# Altium schematic sheet nrf51822.SchDoc
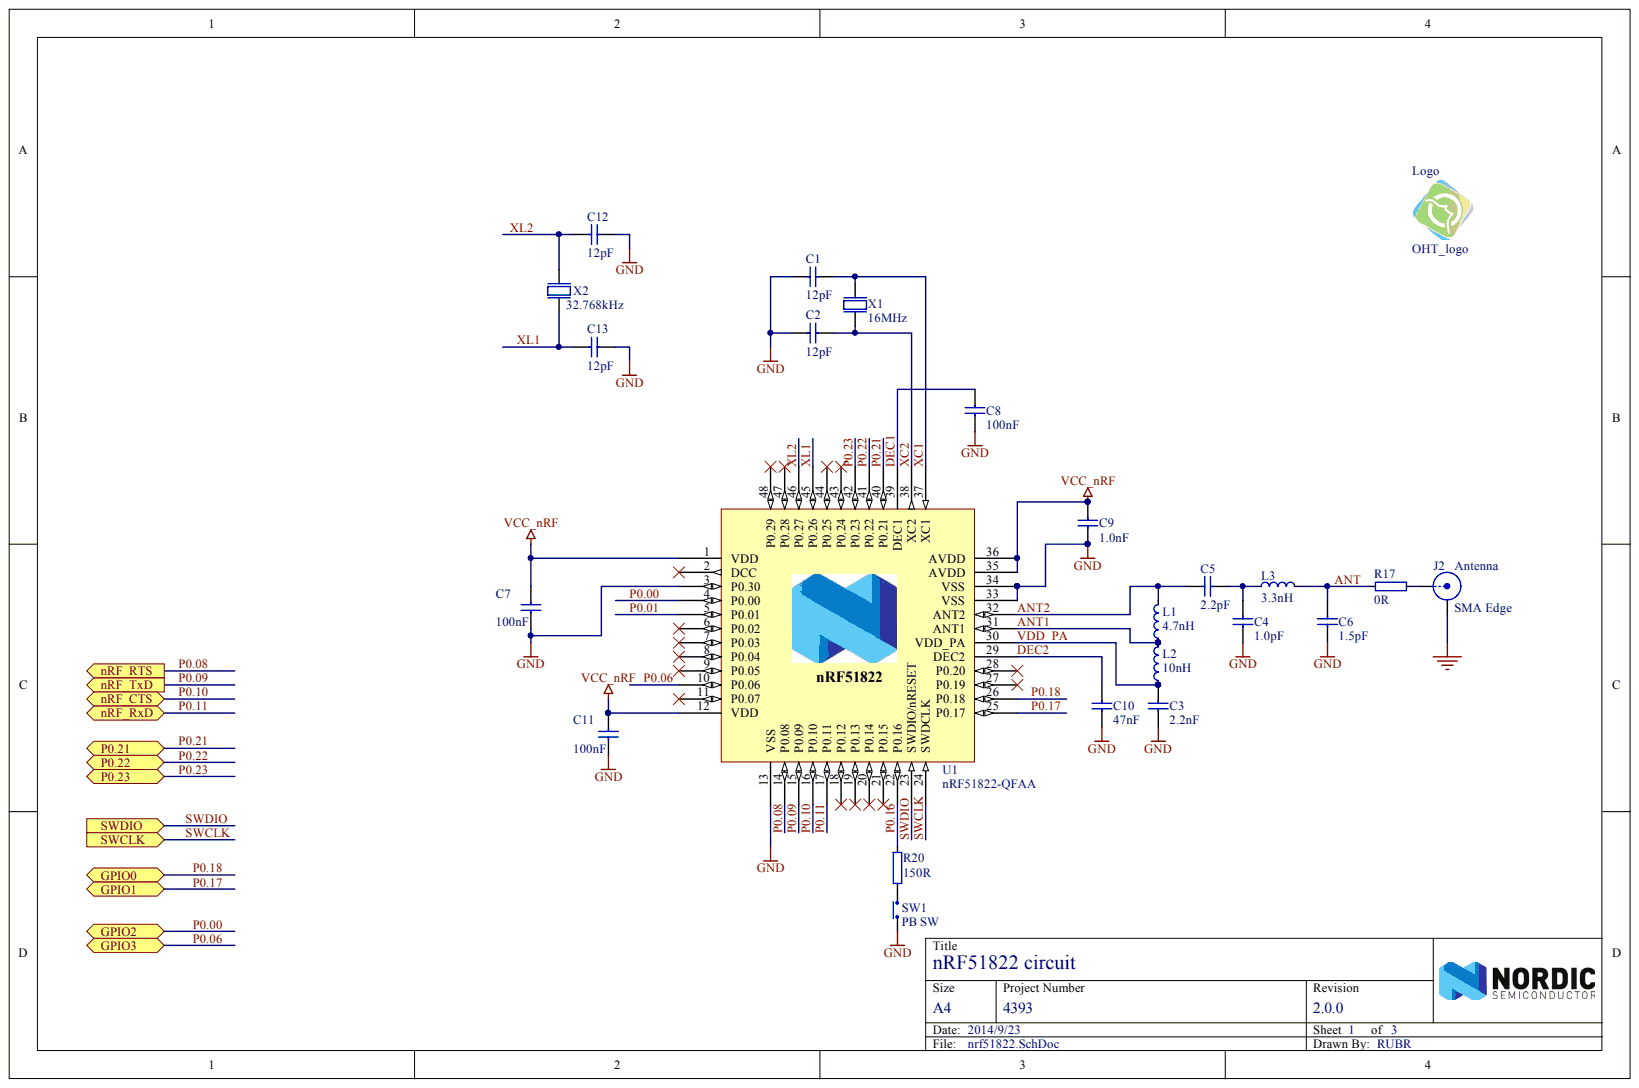
[diagram p0: nrf51822.SchDoc]

## Parts
C1  12pF  [0402]
C2  12pF  [0402]
C3  2.2nF  [0402]
C4  1.0pF  [0402]
C5  2.2pF  [0402]
C6  1.5pF  [0402]
C7  100nF  [0402]
C8  100nF  [0402]
C9  1.0nF  [0402]
C10  47nF  [0402]
C11  100nF  [0402]
C12  12pF  [0402]
C13  12pF  [0402]
J2  SMA Edge  [SMA-EDGE]
L1  4.7nH  [0402]
L2  10nH  [0402]
L3  3.3nH  [0402]
Logo  OHT_logo  [OHTCOM_logo]
R17  0R  [0402]
R20  150R  [0402]
SW1  PB SW  [ALPS_SKHUxxx010_WO_GND]
U1  nRF51822-QFAA  [QFN-48]
X1  16MHz  [BT-XTAL_2520]
X2  32.768kHz  [FC-135]

## Ports
GPIO0, GPIO1, GPIO2, GPIO3, NRF_CTS, NRF_RTS, NRF_RXD, NRF_TXD, P0.21, P0.22, P0.23, SWCLK, SWDIO

## Nets
GND: C1.2 C2.2 C3.2 C4.2 C6.2 C7.2 C8.2 C9.2 C10.2 C11.2 C12.2 C13.2 J2.2 SW1.2 U1.3(P0.30) U1.13(VSS) U1.33(VSS) U1.34(VSS) U1.49 X1.2 X1.4
VCC_NRF: C7.1 C9.1 C11.1 U1.1(VDD) U1.12(VDD) U1.35(AVDD) U1.36(AVDD)
ANT: C6.1 L3.2 R17.1
ANT1: L1.1 L2.2 U1.31(ANT1)
ANT2: C5.1 L1.2 U1.32(ANT2)
DEC1: C8.1 U1.39(DEC1)
DEC2: C10.1 U1.29(DEC2)
P0.00: U1.4(P0.00) ; port GPIO2
P0.01: U1.5(P0.01)
P0.06: U1.10(P0.06) ; port GPIO3
P0.08: U1.14(P0.08) ; port NRF_RTS
P0.09: U1.15(P0.09) ; port NRF_TXD
P0.10: U1.16(P0.10) ; port NRF_CTS
P0.11: U1.17(P0.11) ; port NRF_RXD
P0.16: R20.2 U1.22(P0.16)
P0.17: U1.25(P0.17) ; port GPIO1
P0.18: U1.26(P0.18) ; port GPIO0
P0.21: U1.40(P0.21) ; port P0.21
P0.22: U1.41(P0.22) ; port P0.22
P0.23: U1.42(P0.23) ; port P0.23
SWCLK: U1.24(SWDCLK) ; port SWCLK
SWDIO: U1.23(SWDIO/nRESET) ; port SWDIO
VDD_PA: C3.1 L2.1 U1.30(VDD_PA)
XC1: C1.1 U1.37(XC1) X1.1
XC2: C2.1 U1.38(XC2) X1.3
XL1: C13.1 U1.45(P0.26) X2.2
XL2: C12.1 U1.46(P0.27) X2.1
NetC4_1: C4.1 C5.2 L3.1
NetJ2_1: J2.1 R17.2
NetR20_1: R20.1 SW1.1

Not connected: U1 2(DCC) 6(P0.02) 7(P0.03) 8(P0.04) 9(P0.05) 11(P0.07) 18(P0.12) 19(P0.13) 20(P0.14) 21(P0.15) 27(P0.19) 28(P0.20) 43(P0.24) 44(P0.25) 47(P0.28) 48(P0.29)

## Library data of the parts
C1 C2 C12 C13 = C0402-12p: Capacitor, NP0, ?%; Artikkelnr Noca: 20029159; Database Table Name: Capacitors$; Note: 50V; Component Kind: Standard; LatestRevisionDate: 2011-05-11; Library Name: Nordic Passive DB.DbLib; Part Number: C0402-12p; Pin Count: 2; Published: 2009-05-08; Value: 12pF; Publisher: Nordic Semiconductor
C3 = C0402-2n2: Capacitor, X7R, ?0%; Artikkelnr Noca: 20039221; Database Table Name: Capacitors$; Note: 50V; Component Kind: Standard; LatestRevisionDate: 2009-11-23; Library Name: Nordic Passive DB.DbLib; Part Number: C0402-2n2; Pin Count: 2; Published: 2009-05-08; Value: 2.2nF; Publisher: Nordic Semiconductor
C4 = C0402-1.0p: Capacitor, NP0, ?.1pF; Artikkelnr Noca: 20029140; Database Table Name: Capacitors$; Note: 50V; Component Kind: Standard; LatestRevisionDate: 2009-11-23; Library Name: Nordic Passive DB.DbLib; Part Number: C0402-1.0p; Pin Count: 2; Published: 2009-05-08; Value: 1.0pF; Publisher: Nordic Semiconductor
C5 = C0402-2.2p: Capacitor, NP0, ?.1pF; Artikkelnr Noca: 20029145; Database Table Name: Capacitors$; Note: 50V; Component Kind: Standard; LatestRevisionDate: 2009-05-08; Library Name: Nordic Passive DB.DbLib; Part Number: C0402-2.2p; Pin Count: 2; Published: 2009-05-08; Value: 2.2pF; Publisher: Nordic Semiconductor
C6 = C0402-1.5p: Capacitor, NP0, ?.1pF; Artikkelnr Noca: 20029139; Database Table Name: Capacitors$; Note: 50V; Component Kind: Standard; LatestRevisionDate: 2009-11-23; Library Name: Nordic Passive DB.DbLib; Part Number: C0402-1.5p; Pin Count: 2; Published: 2009-05-08; Value: 1.5pF; Publisher: Nordic Semiconductor
C7 C8 C11 = C0402-100n: Capacitor, X7R, ?0%; Artikkelnr Noca: 20039200; Database Table Name: Capacitors$; Note: 16V; Component Kind: Standard; LatestRevisionDate: 2009-11-23; Library Name: Nordic Passive DB.DbLib; Part Number: C0402-100n; Pin Count: 2; Published: 2009-05-08; Value: 100nF; Publisher: Nordic Semiconductor
C9 = C0402-1n0: Capacitor, X7R, ?0%; Artikkelnr Noca: 20039223; Database Table Name: Capacitors$; Note: 50V; Component Kind: Standard; LatestRevisionDate: 2009-11-23; Library Name: Nordic Passive DB.DbLib; Part Number: C0402-1n0; Pin Count: 2; Published: 2009-05-08; Value: 1.0nF; Publisher: Nordic Semiconductor
C10 = C0402-47n: Capacitor, X7R, ?0%; Artikkelnr Noca: 20039389; Database Table Name: Capacitors$; Note: Chip Cap 10%; Component Kind: Standard; LatestRevisionDate: 2009-05-08; Library Name: Nordic Passive DB.DbLib; Part Number: C0402-47n; Pin Count: 2; Published: 2009-05-08; Value: 47nF; Publisher: Nordic Semiconductor
J2 = SMA-Edge-Con: SMA Edge connector; Artikkelnr Noca: 55029004; Database Table Name: Connectors$; Component Kind: Standard; LatestRevisionDate: 2009-12-12; Library Name: Nordic Passive DB.DbLib; Part Number: SMA-Edge-Con; Pin Count: 2; Published: 2009-12-12; Value: SMA Edge; Publisher: Nordic Semiconductor
L1 = L0402-4n7: Inductor, 160mA, ?.1nH; Manufacturer: Murata; Manufacturer Part Number: LQP15MN4N7B02D; Artikkelnr Noca: 33029164; Database Table Name: Inductors$; Note: High frequency chip inductor ?%; Component Kind: Standard; Datasheet: http://search.murata.co.jp/Ceramy/image/img/PDF/ENG/L0075S0084LQP15M.pdf; LatestRevisionDate: 2012-09-19; Library Name: Nordic Passive DB.DbLib; Part Number: L0402-4n7; Pin Count: 2; Published: 2009-05-08; Supplier: Digi-Key; Supplier part number: 490-1132-1-ND; Value: 4.7nH; Publisher: Nordic Semiconductor
L2 = L0402-10n: Inductor, 100mA, ?%; Manufacturer: Murata; Manufacturer Part Number: LQP15MN10NG02D; Artikkelnr Noca: 33029425; Database Table Name: Inductors$; Note: High frequency chip inductor ?%; Component Kind: Standard; Datasheet: http://search.murata.co.jp/Ceramy/image/img/PDF/ENG/L0075S0084LQP15M.pdf; LatestRevisionDate: 2012-09-19; Library Name: Nordic Passive DB.DbLib; Part Number: L0402-10n; Pin Count: 2; Published: 2010-07-12; Supplier: Mouser; Supplier part number: 81-LQP15MN10NG02D; Value: 10nH; Publisher: Nordic Semiconductor
L3 = L0402-3n3: Inductor, 190mA, ?.1nH; Manufacturer: Murata; Manufacturer Part Number: LQP15MN3N3B02D; Artikkelnr Noca: 33029369; Database Table Name: Inductors$; Note: High frequency chip inductor ?%; Component Kind: Standard; Datasheet: http://search.murata.co.jp/Ceramy/image/img/PDF/ENG/L0075S0084LQP15M.pdf; LatestRevisionDate: 2012-09-19; Library Name: Nordic Passive DB.DbLib; Part Number: L0402-3n3; Pin Count: 2; Published: 2009-05-08; Supplier: Digi-Key; Supplier part number: 490-1130-1-ND; Value: 3.3nH; Publisher: Nordic Semiconductor
Logo = OHT_logo: OHTCOM logo; Component Kind: Standard; Library Name: ohtcom.IntLib
R17 = RES_0420: Resistor, ?%, 0.063W; Part Number: R0402-0R; Artikkelnr Noca: 27049000; Note: Chip Res 5%; Component Kind: Standard; LatestRevisionDate: 2009-11-23; Library Name: pca10000.IntLib; Pin Count: 2; Published: 2009-05-08; Supplier: Farnell; Supplier part number: 146-9661; Value: 0R; Publisher: Nordic Semiconductor
R20 = R0402-150: Resistor, ?%, 0.063W; Artikkelnr Noca: 27049053; Database Table Name: Resistors$; Note: Chip Res 1%; Component Kind: Standard; LatestRevisionDate: 2009-11-23; Library Name: Nordic Passive DB.DbLib; Part Number: R0402-150; Pin Count: 2; Published: 2009-05-08; Supplier: Farnell; Supplier part number: 173-8839; Value: 150R; Publisher: Nordic Semiconductor
SW1 = ALPS_SKHUALE010: ALPS - SKHUALE010 - Tactile Switch, SPNO, SMD, 6.5x6.2x2.5mm; 2nd source part number: 688-SKHUALE010; Artikkelnr Noca: 50019001; Database Table Name: Rele_Switch$; 2nd source Supplier: Mouser; Component Kind: Standard; Datasheet: https://www1.elfa.se/data1/wwwroot/webroot/Z_DATA/03579000.pdf; LatestRevisionDate: 2010-02-01; Library Name: Nordic Discrete DB.DbLib; Manufacturer: Alps; Manufacturer Part Number: SKHUALE010; Part Number: ALPS_SKHUALE010; Pin Count: 4; Published: 2010-02-01; Supplier: Elfa; Supplier part number: 35-790-00; Value: PB SW; Publisher: Nordic Semiconductor
U1 = nRF51822-Q48-6x6_DCDC: Multi-protocol Bluetooth Low Energy and 2.4GHz proprietary system-on-chip; Manufacturer: Nordic Semiconductor; Artikkelnr Noca: 15079200; Database Table Name: nRF Devices$; Note: nRF51822 - QFN48 6x6 0.4mm pitch; Component Kind: Standard; LatestRevisionDate: 2012-07-10; Library Name: Nordic Active DB.DbLib; Manufacturer Part Number: nRF51822-QFAA; Part Number: nRF51822-Q48-6x6_DCDC; Pin Count: 48; Published: 2012-07-08; Supplier: Nordic Semiconductor; Value: nRF51822-QFAA; Publisher: Nordic Semiconductor
X1 = NX2520SA-16MHZ-STD-CSW-5: XTAL SMD 2520, 16MHz, 8pF, ?5ppm; Manufacturer: NDK; Artikkelnr Noca: 22019192; Database Table Name: Crystal_Osc$; Note: XTAL SMD 16.000MHz; Component Kind: Standard; Datasheet: http://www.ndk.com/images/products/catalog/c_NX2520SA-STD-CSW-5_e.pdf; LatestRevisionDate: 2012-06-15; Library Name: Nordic Discrete DB.DbLib; Manufacturer Part Number: NX2520SA-16MHZ-STD-CSW-5; Part Number: NX2520SA-16MHZ-STD-CSW-5; Pin Count: 4; Published: 2012-06-15; Supplier: Digi-Key; Supplier part number: 644-1169-1-ND; Value: 16MHz; Publisher: Nordic Semiconductor
X2 = XTAL_FC-135 32.768k: EPSON TOYOCOM - FC-135 32.768KHZ ?0PPM,9.0PF - CRYSTAL, SM, WATCH; 2nd source part number: 127-8037; Artikkelnr Noca: 22019091; Database Table Name: Crystal_Osc$; Note: XTAL SMD 32.768kHz, 3215; 2nd source Supplier: Farnell; Component Kind: Standard; Datasheet: http://www.farnell.com/datasheets/57480.pdf; LatestRevisionDate: 2010-10-18; Library Name: Nordic Discrete DB.DbLib; Manufacturer: Epson Toyocom; Manufacturer Part Number: FC-135 32.768KHZ ?0PPM,9.0PF; Part Number: XTAL_FC-135 32.768k; Pin Count: 2; Published: 2010-10-18; Supplier: Digi-Key; Supplier part number: FC-135 32.7680KA-AC-ND; Value: 32.768kHz; Publisher: Nordic Semiconductor
